# Altium schematic sheet TXdispatcherBoard.SchDoc(TXdispatcherBoard)
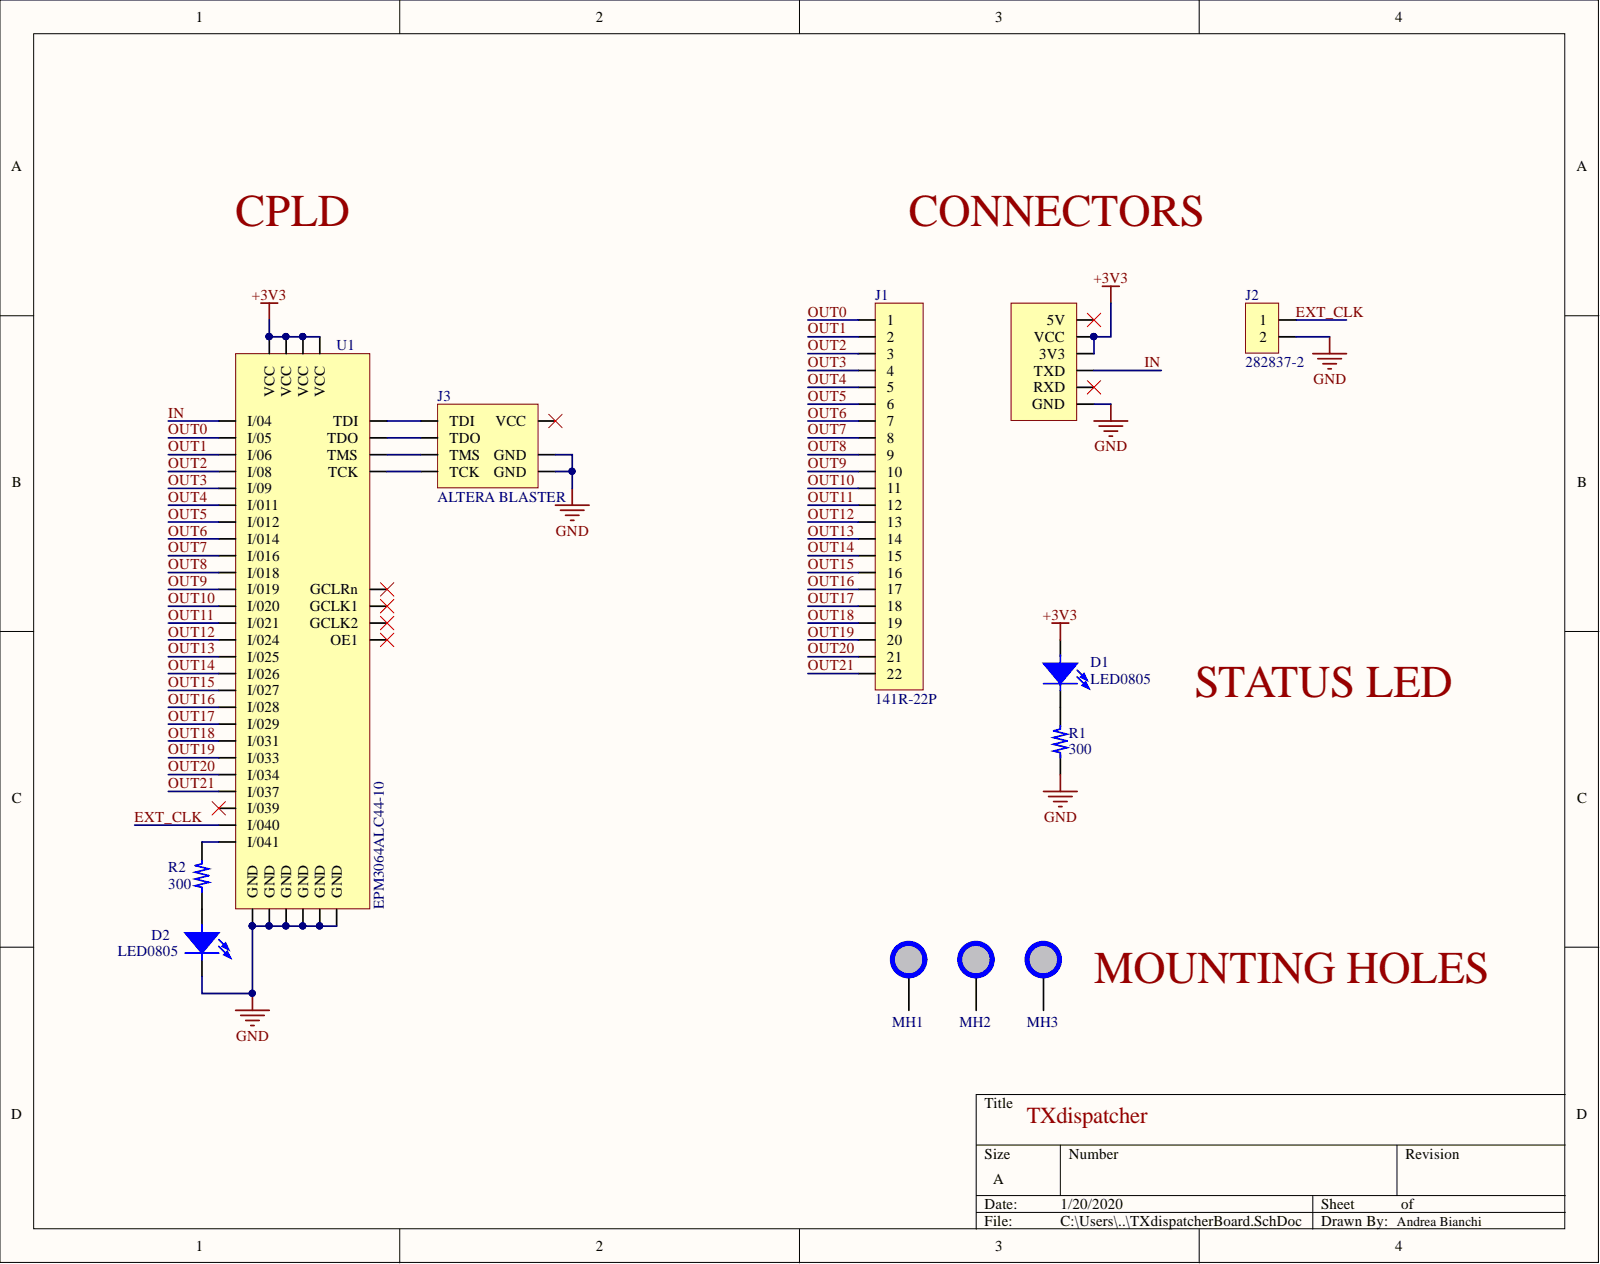
[diagram p0: TXdispatcherBoard.SchDoc]

## Parts
D1  LED0805  [LED0805]  (no BOM)
D2  LED0805  [LED0805]  (no BOM)
J1  141R-22P  [141R-22P]
J2  282837-2  [282837-2]
J3  ALTERA BLASTER  [ALTERA_BLASTER]
J4  USB_FTDI_CONVERTER  [usb_ftdi]  (value not drawn)
MH1  MH 3.2mm NP  [MH3.0mm]  (value not drawn)  (no BOM)
MH2  MH 3.2mm NP  [MH3.0mm]  (value not drawn)  (no BOM)
MH3  MH 3.2mm NP  [MH3.0mm]  (value not drawn)  (no BOM)
R1  300  [R0805]
R2  300  [R0805]
U1  EPM3064ALC44-10  [PLCC44_DIP]

## Nets
+3V3: D1.2 J4.2(VCC) J4.3(3V3) U1.3(VCC) U1.15(VCC) U1.23(VCC) U1.35(VCC)
GND: D2.1 J2.2 J3.2(GND) J3.10(GND) J4.6(GND) R1.1 U1.10(GND) U1.17(GND) U1.22(GND) U1.30(GND) U1.36(GND) U1.42(GND)
EXT_CLK: J2.1 U1.40(I/040)
IN: J4.4(TXD) U1.4(I/04)
OUT0: J1.1 U1.5(I/05)
OUT1: J1.2 U1.6(I/06)
OUT2: J1.3 U1.8(I/08)
OUT3: J1.4 U1.9(I/09)
OUT4: J1.5 U1.11(I/011)
OUT5: J1.6 U1.12(I/012)
OUT6: J1.7 U1.14(I/014)
OUT7: J1.8 U1.16(I/016)
OUT8: J1.9 U1.18(I/018)
OUT9: J1.10 U1.19(I/019)
OUT10: J1.11 U1.20(I/020)
OUT11: J1.12 U1.21(I/021)
OUT12: J1.13 U1.24(I/024)
OUT13: J1.14 U1.25(I/025)
OUT14: J1.15 U1.26(I/026)
OUT15: J1.16 U1.27(I/027)
OUT16: J1.17 U1.28(I/028)
OUT17: J1.18 U1.29(I/029)
OUT18: J1.19 U1.31(I/031)
OUT19: J1.20 U1.33(I/033)
OUT20: J1.21 U1.34(I/034)
OUT21: J1.22 U1.37(I/037)
NetD1_1: D1.1 R1.2
NetD2_2: D2.2 R2.1
NetJ3_1: J3.1(TCK) U1.32(TCK)
NetJ3_3: J3.3(TDO) U1.38(TDO)
NetJ3_5: J3.5(TMS) U1.13(TMS)
NetJ3_9: J3.9(TDI) U1.7(TDI)
NetR2_2: R2.2 U1.41(I/041)

Not connected: J3 4(VCC); J4 1(5V) 5(RXD); MH1 1; MH2 1; MH3 1; U1 1(GCLRn) 2(GCLK2) 39(I/039) 43(GCLK1) 44(OE1)

## Library data of the parts
D1 D2 = LED0805: LED0805 any color; Library Name: TXdispatcherBoard.SchLib
J2 = 282837-2: Conn Terminal Blocks F 2 POS 5.08mm Solder ST Thru-Hole 13.5A/Contact; Manufacturer: TE Connectivity; Wire Gauge (Min): 16AWG; Wire Gauge (Max): 28AWG; Wire/Cable Gauge: 30AWG; Voltage Rating (AC): 250V; Voltage: 300V; Thread Size: M3; Tail Length: 3.5mm; Side Stackable: Yes (With); Screwless Terminal Block: No (Without); Plating: Brass; Number of Positions Per Level: 2; Number of Levels: 1; Max Current Rating: 13.5A; Gender: Female; Connector Type: Receptacle; Approvals: UL; Width: 11.5mm; Voltage Rating: 250V; Termination: Solder; Supplier Part Number 1: A113320-ND; Supplier 1: Digi-Key; Stock 1: 133598; Screw Flange: No (Without); RoHS: Yes; REACH SVHC: Unknown; Radiation Hardening: No; Pricing 1: 1 = 1.04000, 10 = 0.64700, 25 = 0.55400, 50 = 0.49780, 100 = 0.48750, 250 = 0.41492, 500 = 0.39416, 1000 = 0.35267, 5000 = 0.27591 (USD); Pitch: 5.08mm; Packaging: Bulk; Package Quantity: 300; Orientation: Straight; Number of Rows: 1; Number of Positions: 2; Number of Poles: 2; Number of Contacts: 2; Number of Circuits: 2; Mount: Through Hole; Max Voltage Rating (AC): 250V; Max Supply Voltage (AC): 250V; Manufacturer Part Number: 282837-2; Length: 10.76mm; Lead Free: Lead Free; Interlock: Yes (With); Housing Material: Polyamide; Housing Color: Green; Height: 11.5mm; Flammability Rating: UL94 V-0; ELV: Compliant; Depth: 8.2mm; Current Rating: 13.5A; Current: 13.5A; Contact Plating: Tin; Contact Material: Brass; ComponentLink10URL: https://datasheet.ciiva.com/18620/eng-cd-282837-g3-18620917.pdf?src-supplier=Digi-Key; ComponentLink10Description: https://datasheet.ciiva.com/18620/eng-cd-282837-g3-18620917.pdf?src-supplier=Digi-Key; ComponentLink9URL: https://datasheet.ciiva.com/16649/eng-cs-1308389-eurostyle-terminal-blocks-0607-16649391.pdf?src-supplier=Avnet+Europe; ComponentLink9Description: https://datasheet.ciiva.com/16649/eng-cs-1308389-eurostyle-terminal-blocks-0607-16649391.pdf?src-supplier=Avnet+Europe; ComponentLink8URL: https://datasheet.ciiva.com/823/eng-cd-282837-g3-823439.pdf?src-supplier=Digi-Key; ComponentLink8Description: https://datasheet.ciiva.com/823/eng-cd-282837-g3-823439.pdf?src-supplier=Digi-Key; ComponentLink7URL: https://datasheet.ciiva.com/30084/eng-cs-1308389-eurostyle-terminal-blocks-0607-30084269.pdf?src-supplier=Onlinecomponents.com; ComponentLink7Description: https://datasheet.ciiva.com/30084/eng-cs-1308389-eurostyle-terminal-blocks-0607-30084269.pdf?src-supplier=Onlinecomponents.com; ComponentLink6URL: https://datasheet.ciiva.com/14606/70086281-14606908.pdf?src-supplier=Allied+Electronics; ComponentLink6Description: https://datasheet.ciiva.com/14606/70086281-14606908.pdf?src-supplier=Allied+Electronics; ComponentLink5URL: https://datasheet.ciiva.com/7978/1650926-7978569.pdf?src-supplier=Element14; ComponentLink5Description: https://datasheet.ciiva.com/7978/1650926-7978569.pdf?src-supplier=Element14; ComponentLink4URL: https://datasheet.ciiva.com/11758/0900766b80e7dc97-11758677.pdf?src-supplier=RSComponents; ComponentLink4Description: https://datasheet.ciiva.com/11758/0900766b80e7dc97-11758677.pdf?src-supplier=RSComponents; ComponentLink3URL: https://datasheet.ciiva.com/19467/750688-19467564.pdf?src-supplier=Jameco; ComponentLink3Description: https://datasheet.ciiva.com/19467/750688-19467564.pdf?src-supplier=Jameco; ComponentLink2URL: https://datasheet.ciiva.com/16305/eng-cs-1308389-eurostyle-terminal-blocks-0607-16305248.pdf?src-supplier=Future+Electronics; ComponentLink2Description: https://datasheet.ciiva.com/16305/eng-cs-1308389-eurostyle-terminal-blocks-0607-16305248.pdf?src-supplier=Future+Electronics; ComponentLink1URL: https://datasheet.ciiva.com/819/eng-cs-1308389-eurostyle-terminal-blocks-0607-819104.pdf?src-supplier=Avnet; ComponentLink1Description: https://datasheet.ciiva.com/819/eng-cs-1308389-eurostyle-terminal-blocks-0607-819104.pdf?src-supplier=Avnet; Component Kind: Standard; Color: Green; Closed End: No (Without); Library Name: TXdispatcherBoard.SchLib
J3 = ALTERA_JTAG: Component Kind: Standard; Library Name: TXdispatcherBoard.SchLib
MH1-MH3 = MHNP: Mounting hole 3.2mm non plated; Library Name: TXdispatcherBoard.SchLib
R1 R2 = R0805: Description: 300; Component Kind: Standard; Library Name: TXdispatcherBoard.SchLib
U1 = EPM3064ALC44-10: IC CPLD 64MC 10NS 44PLCC; Manufacturer: Intel Altera; Speed Grade: 10; Operating Supply Voltage: 3.3V; Number of Programmable I/O: 34; Number of Macrocells: 64; Number of Logic Elements/Cells: 4; Number of Gates: 1250; Min Supply Voltage: 3V; Memory Type: EEPROM; Max Supply Voltage: 3.6V; Max Frequency: 222.2MHz; Frequency: 125MHz; Width: 16.59mm; Turn-On Delay Time: 10ns; Supplier 1: Digi-Key; Stock 1: 0; RoHS: Yes; Radiation Hardening: No; Propagation Delay: 10ns; Packaging: Rail/Tube; Package Quantity: 130; Number of Pins: 44; Number of Logic Blocks (LABs): 4; Number of I/Os: 34; Mount: Surface Mount; Min Operating Temperature: 0°C; Max Operating Temperature: 70°C; Manufacturer Part Number: EPM3064ALC44-10; Length: 16.59mm; Lead Free: Contains Lead; Height: 3.81mm; ComponentLink3URL: https://datasheet.ciiva.com/1406/m3000a-1406963.pdf?src-supplier=Digi-Key; ComponentLink3Description: https://datasheet.ciiva.com/1406/m3000a-1406963.pdf?src-supplier=Digi-Key; ComponentLink2URL: https://datasheet.ciiva.com/15102/getdatasheetpartid-224088-15102743.pdf?src-supplier=Verical; ComponentLink2Description: https://datasheet.ciiva.com/15102/getdatasheetpartid-224088-15102743.pdf?src-supplier=Verical; ComponentLink1URL: https://datasheet.ciiva.com/29887/1723147-29887635.pdf?src-supplier=Jameco; ComponentLink1Description: https://datasheet.ciiva.com/29887/1723147-29887635.pdf?src-supplier=Jameco; Component Kind: Standard; Case/Package: PLCC; Library Name: TXdispatcherBoard.SchLib
J1 = 141R-22P: ComponentLink1URL: https://www.dinkle.com/en/terminal/outpagepdf.php?dpid=94&outpdf=1; ComponentLink1Description: Datasheet; Component Kind: Standard; Library Name: TXdispatcherBoard.SchLib
J4 = USB_FTDI_CONVERTER: Description: USB_FTDI_CONVERTER; Component Kind: Standard; Library Name: TXdispatcherBoard.SchLib
